# Altium schematic sheet MQTT IN SCHEMATIC.SchDoc
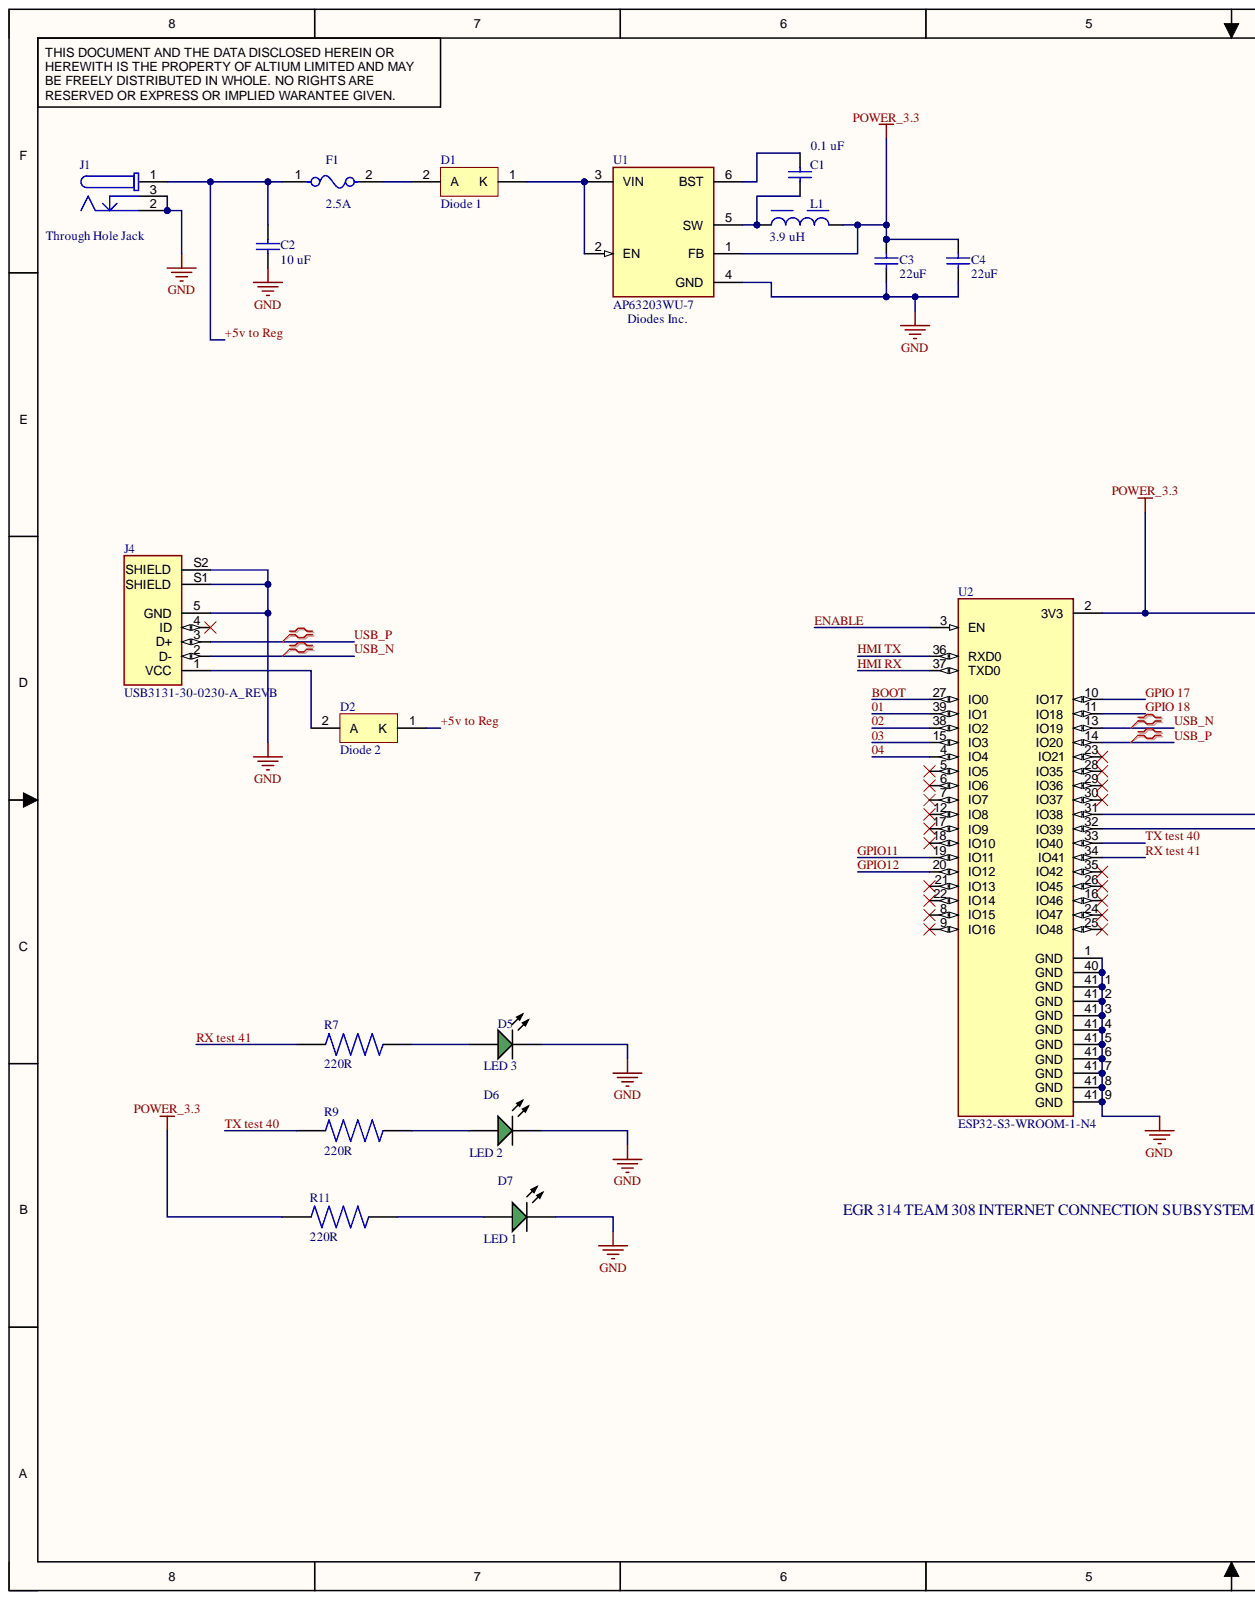
[diagram p0: MQTT IN SCHEMATIC.SchDoc, left]
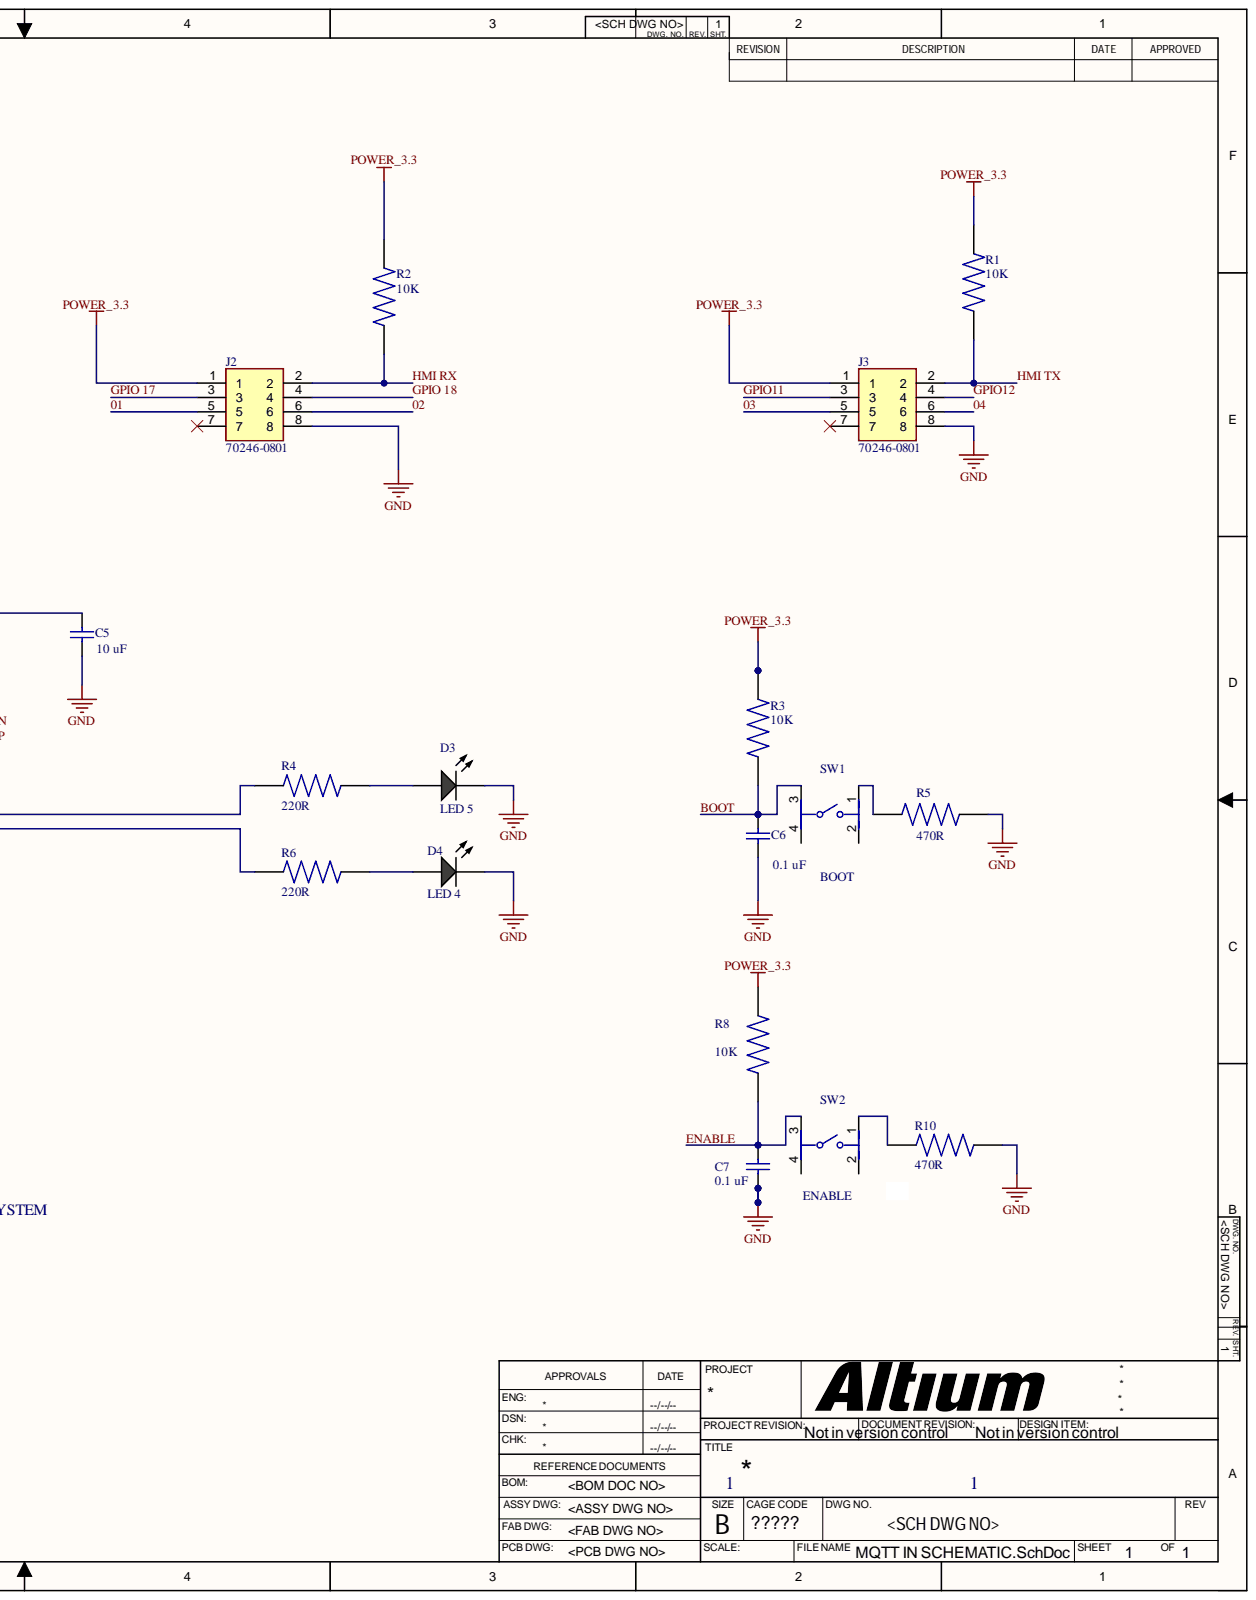
[diagram p1: MQTT IN SCHEMATIC.SchDoc, right]

## Parts
C1  0.1 uF  [CAPC1812(4532)125_M]
C2  10 uF  [CAPC1812(4532)125_M]
C3  22uF  [CAPC2013X135X45LL10T25]
C4  22uF  [CAPC2013X135X45LL10T25]
C5  10 uF  [CAPC1812(4532)125_M]
C6  0.1 uF  [CAPC1812(4532)125_M]
C7  0.1 uF  [CAPC1812(4532)125_M]
D1  Diode 1  [ONSC-SMC-2-403-03_V]
D2  Diode 2  [ONSC-SMC-2-403-03_V]
D3  LED 5  [AVAG-HSMX-C170_V]
D4  LED 4  [AVAG-HSMX-C170_V]
D5  LED 3  [AVAG-HSMX-C170_V]
D6  LED 2  [AVAG-HSMX-C170_V]
D7  LED 1  [AVAG-HSMX-C170_V]
F1  2.5A  [FP-0154-MFG]
J1  Through Hole Jack  Mount: Through Hole  [694106301002]
J2  70246-0801  [SHDR8W99P254_2X4_1778X889X927P]
J3  70246-0801  [SHDR8W99P254_2X4_1778X889X927P]
J4  USB3131-30-0230-A_REVB  [GCT_USB3131-30-0230-A_REVB]
L1  3.9 uH  [WE-GFH_4532]
R1  10K  [RESC3146X65N]
R2  10K  [RESC3146X65N]
R3  10K  [RESC3146X65N]
R4  220R  [RESC3146X65N]
R5  470R  [RESC3146X65N]
R6  220R  [RESC3146X65N]
R7  220R  [RESC3146X65N]
R8  10K  [RESC3146X65N]
R9  220R  [RESC3146X65N]
R10  470R  [RESC3146X65N]
R11  220R  [RESC3146X65N]
SW1  BOOT  [FP-PTS645SH50SMTR92LFS-MFG]
SW2  ENABLE  [FP-PTS645SH50SMTR92LFS-MFG]
U1  AP63203WU-7  Manufacturer: Diodes Inc.  [FP-TSOT26-MFG]
U2  ESP32-S3-WROOM-1-N4  [XCVR_ESP32-S3-WROOM-1-N4]

## Nets
GND: C2.1 C3.1 C4.1 C5.1 C6.1 C7.1 D3.2 D4.2 D5.2 D6.2 D7.2 J1.2 J1.3 J2.8 J3.8 J4.5(GND) J4.S1(SHIELD) J4.S2(SHIELD) R5.2 R10.1 U1.4(GND) U2.1(GND) U2.40(GND) U2.41_1(GND) U2.41_2(GND) U2.41_3(GND) U2.41_4(GND) U2.41_5(GND) U2.41_6(GND) U2.41_7(GND) U2.41_8(GND) U2.41_9(GND)
POWER_3.3: C3.2 C4.2 C5.2 J2.1 J3.1 L1.2 R1.2 R2.2 R3.2 R8.2 R11.2 U1.1(FB) U2.2(3V3)
01: J2.5 U2.39(IO1)
02: J2.6 U2.38(IO2)
03: J3.5 U2.15(IO3)
04: J3.6 U2.4(IO4)
+5v to Reg: C2.2 D2.1 F1.1 J1.1
BOOT: C6.2 R3.1 SW1.3 U2.27(IO0)
ENABLE: C7.2 R8.1 SW2.3 U2.3(EN)
GPIO11: J3.3 U2.19(IO11)
GPIO12: J3.4 U2.20(IO12)
GPIO 17: J2.3 U2.10(IO17)
GPIO 18: J2.4 U2.11(IO18)
HMI RX: J2.2 R2.1 U2.37(TXD0)
HMI TX: J3.2 R1.1 U2.36(RXD0)
RX test 41: R7.2 U2.34(IO41)
TX test 40: R9.2 U2.33(IO40)
USB_N: J4.2(D-) U2.13(IO19)
USB_P: J4.3(D+) U2.14(IO20)
NetC1_1: C1.1 L1.1 U1.5(SW)
NetC1_2: C1.2 U1.6(BST)
NetD1_1: D1.1 U1.2(EN) U1.3(VIN)
NetD1_2: D1.2 F1.2
NetD2_2: D2.2 J4.1(VCC)
NetD3_1: D3.1 R4.1
NetD4_1: D4.1 R6.1
NetD5_1: D5.1 R7.1
NetD6_1: D6.1 R9.1
NetD7_1: D7.1 R11.1
NetR4_2: R4.2 U2.31(IO38)
NetR5_1: R5.1 SW1.1
NetR6_2: R6.2 U2.32(IO39)
NetR10_2: R10.2 SW2.1

Not connected: J2 7; J3 7; J4 4(ID); SW1 2 4; SW2 2 4; U2 5(IO5) 6(IO6) 7(IO7) 8(IO15) 9(IO16) 12(IO8) 16(IO46) 17(IO9) 18(IO10) 21(IO13) 22(IO14) 23(IO21) 24(IO47) 25(IO48) 26(IO45) 28(IO35) 29(IO36) 30(IO37) 35(IO42)

## Library data of the parts
C1 C2 C5-C7 = CMP-1040-01539-1: CAP 470nF 50V ±10% 1812 (4532 Metric) Thickness 1.25mm SMD; Case-EIA: 1812; Case-Metric: 4532; Tolerance: Â±10%; Component Kind: Standard; Library Name: Altium Content Vault; Max Thickness: 1.25 mm; Rated Voltage: 50 V; Technology: SMT; Value: 470nF
C3 C4 = CMP-1662-00015-2: Chip Capacitor, 4.7 uF, +/- 10%, 16 V, -55 to 85 degC, 0805 (2012 Metric), RoHS, Tape and Reel; PartNumber: GRM21BR61C475KA88L; Capacitance: 4.7 uF; Case\/Package: 0805; Type (Capacitors): X5R; Voltage Rating: 16 V; Case Code (Imperial): 0805; Case Code (Metric): 2012; Component Kind: Standard; ComponentLink1Description: Manufacturer URL; ComponentLink1URL: http://www.murata.com/; ComponentLink2Description: Datasheet; ComponentLink2URL: http://search.murata.co.jp/Ceramy/image/img/A01X/G101/ENG/GRM21BR61C475KA88-01.pdf; DatasheetVersion: 03/2016; Height: 1.25 mm; Length: 2 mm; Library Name: Altium Content Vault; Max Operating Temperature: 85 degC; Min Operating Temperature: -55 degC; Mount: Surface Mount; Mounting Technology: Surface Mount; Package Quantity: 3000; PackageDescription: 2-Pin Surface Mount Device, Body 2 x 1.25 mm; PackageReference: 0805; Packaging: Tape and Reel; Pins: 2; Radiation Hardening: No; REACH SVHC: No SVHC; RoHSCompliant: true; Tolerance: 10%; Voltage Rating (DC): 16 V; Width: 1.25 mm
D1 D2 = CMP-1055-00380-1: Schottky Power Rectifier, 2-Pin SMC, Pb-Free, Tape and Reel; Manufacturer: On Semiconductor; PartNumber: MBRS340T3G; Configuration: Single; IFSM Max (A): 80; Component Kind: Standard; ComponentLink1Description: Manufacturer URL; ComponentLink1URL: http://www.onsemi.com/; ComponentLink2Description: Datasheet; ComponentLink2URL: http://www.onsemi.cn/pub_link/Collateral/MBRS340T3-D.PDF; ComponentLink3Description: Package Specification; ComponentLink3URL: http://www.onsemi.com/pub_link/Collateral/403-03.PDF; DatasheetVersion: Rev. 11; Library Name: Altium Content Vault; Mounting Technology: Surface Mount; PackageDescription: 2-Pin Small Molded Package, J-Bend Lead, Body 5.845 x 6.855 mm; PackageReference: SMC-2-403-03; PackageVersion: Rev. E, 08/2005; Packing: Tape and Reel; RoHS: TRUE
D3-D7 = CMP-2000-05062-1: Chip LED, Green, 2.2 V, -40 to 85 degC, 2-Pin SMD, Pb-Free, Tape and Reel; Manufacturer: Avago; Bulb Size: 1.25mmx1.4mm; Dominant Wavelength: 572nm; External Depth: 0.8mm; External Length / Height: 2mm; External Width: 1.25mm; Forward Current If: 20mA; Forward Voltage: 2.2V; Forward Voltage VF Max: 2.6V; LED Type: Standard; Lens Shape: Rectangular; Light Area Height: 1mm; Luminous Intensity: 15mcd; Luminous Intensity Min: 4mcd; No. of Pins: 2; Opto Mounting Orientation: Top; Peak Wavelength: 570nm; PIV Max: 5V; SVHC: NoSVHC(16-Jun-2014); Viewing Angle: 170deg; Component Kind: Standard; Datasheet URL: https://datasheet.ciiva.com/29280/180495-da-01-en-chip-led-hsmg-c170-gelb-gruen-29280971.pdf; Datasheet Version: Oct-08; Dominant Wavelength Typ: 572nm; Forward Current If Max: 20mA; LED Colour: Green; LED Mounting: SMD; Library Name: Altium Content Vault; Luminous Intensity Typ: 15mcd; Manufacturer URL: http://www.avagotech.com/; Mounting Type: SMD; MSL: MSL2A-4weeks; Operating Temperature Max: 85degC; Operating Temperature Min: -30degC; Operating Temperature Range: -30degCto+85degC; Opto Case Style: SMD; Package Description: 2-Pin Surface Mount Device, Body 2 x 1.25 mm, Height 0.8 mm; Package Reference: HSMX-C170; Packaging: CutTape; Peak Forward Current: 20mA; Storage Temperature Max: 85degC; Storage Temperature Min: -40degC; Voltage Vf Typ: 2.2V; Wavelength Typ: 570nm
F1 = CMP-2000-05609-2: FUSE BRD MNT 2.5A 125VAC/VDC SMD; Manufacturer: Littelfuse; Manufacturer Part Number: 015402.5DRT; Fuse Blow Speed: Slow; Max Fuse Ac Voltage: 125V; Automotive: No; Category: Fuse; Component Kind: Standard; ComponentLink2Description: Datasheet; ComponentLink2URL: https://www.littelfuse.com/~/media/electronics/datasheets/fuses/littelfuse_fuse_154_154t_154l_154tl_datasheet.pdf.pdf; Device Class L1: Circuit Protection; Device Class L2: Fuses; Device Class L3: unset; Digikey Description: FUSE BRD MNT 2.5A 125VAC/VDC SMD; Fuse Current: 2.5A; Height: 3.81mm; Lead Free: Yes; Library Name: Altium Content Vault; Max Fuse Dc Voltage: 125V; Mouser Description: Fuse Chip Slow Blow Acting 2.5A SMD Solder Pad 9.73 X 5.03 X 3.81mm T/R CSA/PSE/UL; Octopart: https://octopart.com/015402.5drt-littelfuse-565920; Package: 0154; RoHS: TRUE; Temperature: -55°C to +125°C; Temperature Range High: +125°C; Temperature Range Low: -55°C
J1 = CMP-1502-00188-2: NexarPartId: 52676100; Connector Type: Power; Current Rating: 5A; Gender: Male; Insulation Resistance: 100MΩ; Number of Contacts: 3; Operating Supply Voltage: 24V; Shielding: Unshielded; Voltage Rating (DC): 20V; Color: Black; Component Kind: Standard; Contact Gender: Female; Contact Material: Gold, Tin; Contact Plating: Tin; Flammability Rating: UL94 V-0; Height: 11mm; Housing Material: Polyamide; Library Name: Altium Content Vault; Max Operating Temperature: 85°C; Min Operating Temperature: -40°C; Packaging: Bulk; REACH SVHC: Unknown; RoHS: Compliant; Termination: Through Hole; Voltage Rating: 24V
J2 J3 = 70246-0801: Connector; Manufacturer_Name: Molex; Component Kind: Standard; Datasheet Link: https://www.molex.com/pdm_docs/sd/702460801_sd.pdf; Height: 9.27mm; Manufacturer_Part_Number: 70246-0801; Mouser Part Number: 538-70246-0801; Mouser Price/Stock: https://www.mouser.co.uk/ProductDetail/Molex/70246-0801?qs=KOdaDPWVOUaw5PENvhTZTA%3D%3D
J4 = USB3131-30-0230-A_REVB: USB - micro B USB 2.0 Receptacle Connector 5 Position Through Hole; Availability: Unavailable; Component Kind: Standard; Library Name: USB3131-30-0230-A_REVB.IntLib; MP: USB3131-30-0230-A_REVB; Package: None; Price: None
L1 = CMP-03913-61645403-1: 7447669182 WE-GFH High Frequency SMT Inductor; Core Material: Ferrite; Current Rating: 300mA; DC Resistance (DCR): 3.11Ω; Inductance: 82µH; Inductance Tolerance: 10%; Self Resonant Frequency: 11MHz; Shielding: Unshielded; Test Frequency: 975kHz; Ambient Temperature Range High: 85°C; Ambient Temperature Range Low: -40°C; Case/Package: Nonstandard; Component Kind: Standard; Height: 3.2mm; Height - Seated (Max): 3.4036mm; Length: 4.5mm; Library Name: Altium Content Vault; Max DC Current: 300mA; Max Operating Temperature: 125°C; Min Operating Temperature: -40°C; Mount: Surface Mount; NexarPartId: 61645403; Packaging: Tape & Reel (TR); REACH SVHC: Unknown; RoHS: Compliant; Tolerance: 10%; Width: 3.2mm
R1-R11 = RC1218FK-07324RL: 324 Ohms Â±1% 1W Chip Resistor Wide 1812 (4532 Metric), 1218 Moisture Resistant Thick Film; MF: Yageo; Check_prices: https://www.snapeda.com/parts/RC1218FK-07324RL/Yageo/view-part/?ref=eda; Component Kind: Standard; Library Name: RC1218FK-07324RL.IntLib; MP: RC1218FK-07324RL; Package: SMD-2 Yageo; SnapEDA_Link: https://www.snapeda.com/parts/RC1218FK-07324RL/Yageo/view-part/?ref=snap
SW1 SW2 = CMP-10040-000028-1: SWITCH TACTILE SPST-NO 0.05A 12V; Actuator Material: Nylon; Actuator Type: Standard; Current Rating: 50mA; Illumination Type: Non-Illuminated; Mechanical Life: 100000Cycles; Operating Force: 200gf; Switch Function: Off-Mom; Throw Configuration: SPST; Case/Package: SMD/SMT; Color: Black; Component Kind: Standard; Contact Material: Bronze; Contact Plating: Silver; Depth: 9mm; Lead Free: Lead Free; Length: 6mm; Library Name: Altium Content Vault; Max Current Rating: 50mA; Max Operating Temperature: 60°C; Max Voltage Rating (DC): 12V; Min Operating Temperature: -20°C; Mount: Surface Mount; Orientation: Straight; Package Quantity: 1000; Packaging: Tape and Reel; REACH SVHC: No SVHC; Termination: Gull Wing; Voltage Rating (DC): 12V
U1 = CMP-12757-000006-1: IC REG BUCK 3.3V 2A TSOT26; Max Junction Temp: +160°C; Max Supply Voltage: 32V; Min Supply Voltage: 3.8V; Nominal Supply Current: 22uA; Number Of Outputs: 1; Output Type: Fixed; Standoff Height: 0.01mm; Switching Frequency: 1.1MHz; Ambient Temperature Range High: +85°C; Ambient Temperature Range Low: -40°C; Automotive: No; Category: IC; Component Kind: Standard; ComponentLink2Description: Datasheet; ComponentLink2URL: https://www.diodes.com/assets/Datasheets/AP63200-AP63201-AP63203-AP63205.pdf; Device Class L1: Integrated Circuits (ICs); Device Class L2: Power Management ICs; Device Class L3: Voltage Regulators - Switching; Digikey Description: IC REG BUCK 3.3V 2A TSOT26; Footprint Url: https://www.diodes.com/assets/Package-Files/TSOT26.pdf; Height: 1mm; Lead Free: Yes; Library Name: Altium Content Vault; Manufacturer Part Number: AP63203WU-7; Mouser Description: AP63203 Series 3.3 V 2 A SMT Fixed Low IQ Synchronous Buck - TSOT-26; Octopart: https://octopart.com/ap63203wu-7-diodes+inc.-98099550; Output Current: 2A; Output Voltage: 3.3V; Package: TSOT26; RoHS: TRUE; Switching Topology: Buck; Temperature: to +160°C; Temperature Range High: +160°C
U2 = ESP32-S3-WROOM-1-N4: MF: {'manufacturer': u'Espressif Systems'}; Availability: Unavailable; Component Kind: Standard; Library Name: ESP32-S3-WROOM-1-N4.IntLib; MP: ESP32-S3-WROOM-1-N4; Package: None; Price: None
